# Altium schematic sheet cat-badge.SchDoc(cat-badge)
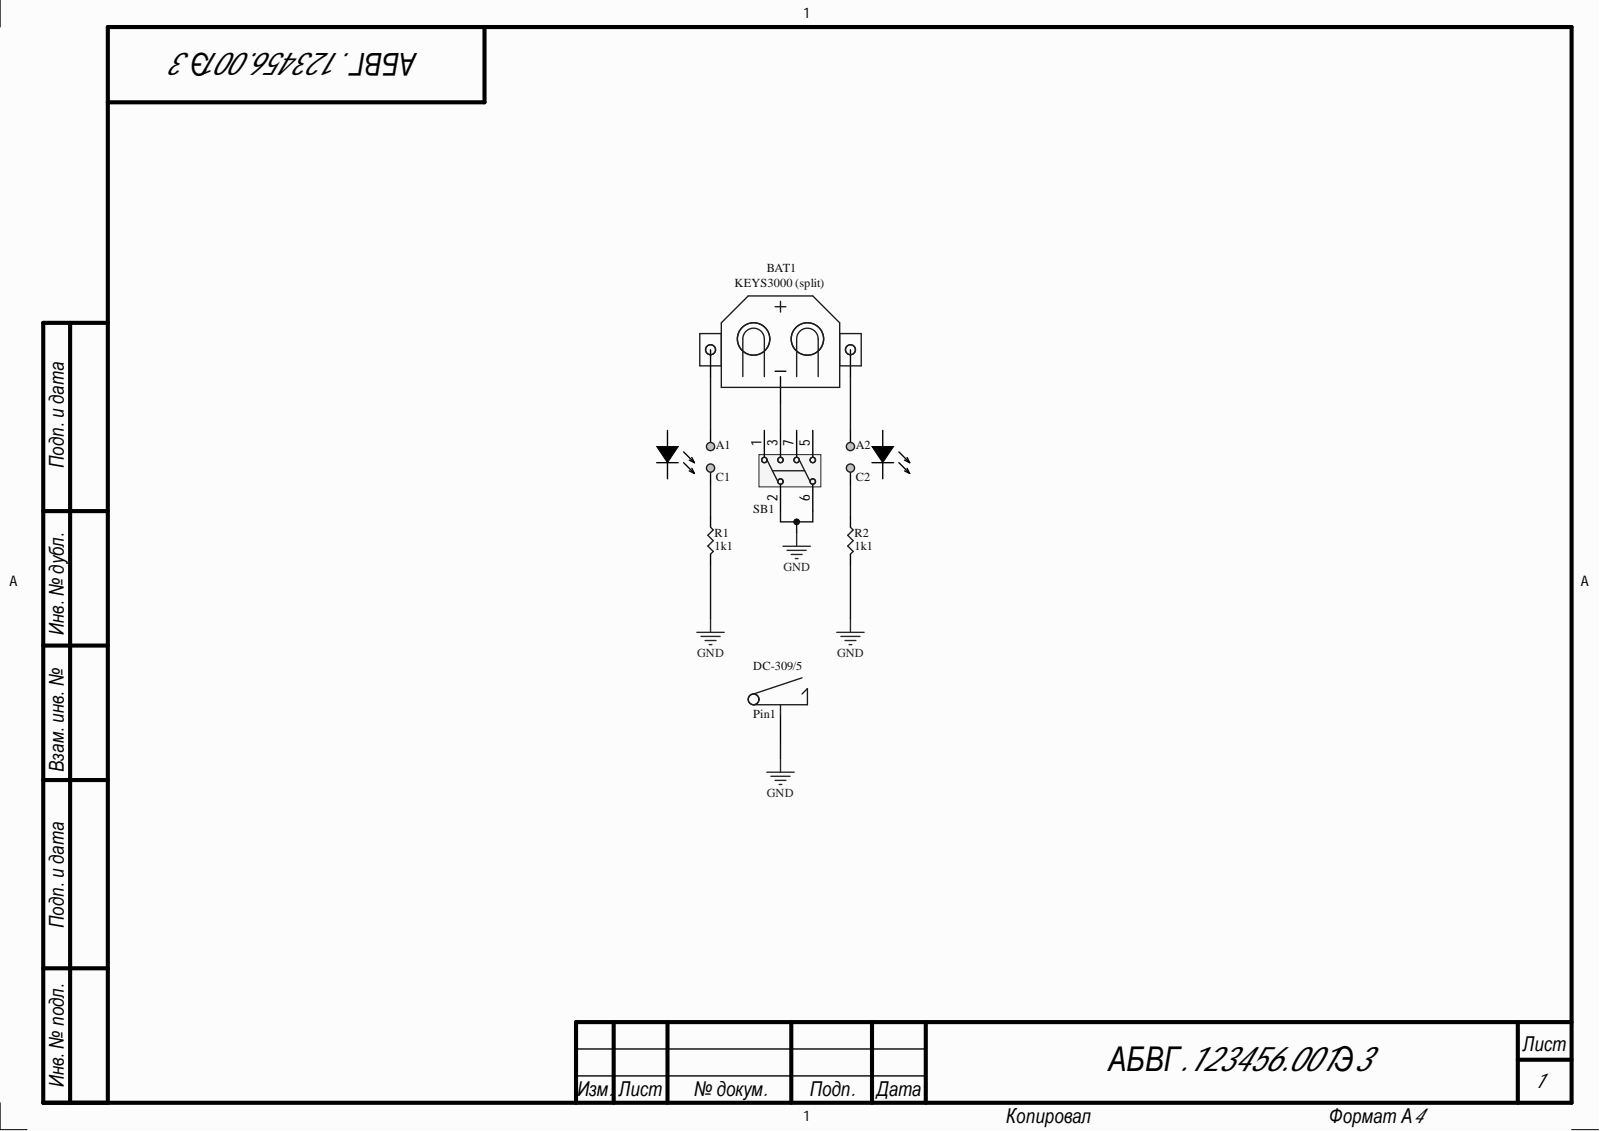
[diagram p0: cat-badge.SchDoc]

## Parts
A1  Test Point  [TP_1.2MM]  (value not drawn)  (no BOM)
A2  Test Point  [TP_1.2MM]  (value not drawn)  (no BOM)
BAT1  KEYS3000 (split)  [KEYS3000_split]
C1  Test Point  [TP_1.2MM]  (value not drawn)  (no BOM)
C2  Test Point  [TP_1.2MM]  (value not drawn)  (no BOM)
Pin1  DC-309/5  [DC-309/5]
R1  1k1  [RES0603]
R2  1k1  [RES0603]
SB1  JS202011JCQN  [JS202011JCQN]  (value not drawn)

## Nets
GND: Pin1.1 R1.1 R2.1 SB1.2 SB1.6
NetA1_1: A1.1 BAT1.3
NetA2_1: A2.1 BAT1.1
NetBAT1_2: BAT1.2 SB1.3
NetC1_1: C1.1 R1.2
NetC2_1: C2.1 R2.2

Not connected: SB1 1 5 7

## Library data of the parts
A1 A2 C1 C2 = TP/R1.2: Database Table Name: Test Point$; Item: TP1.2; Category: Test Point; Part Number: TP/R1.2; Library Name: Lib_Kam.DbLib
BAT1 = KEYS3000 (split): Coin Cell Battery Holders SMT HOLDER FOR CR1220; Manufacturer: KEYSTONE; Database Table Name: Other$; Item: 1220 battery holder split shield; Case: BH611220-P; Category: Coin Cell Battery Holders; Component Kind: Standard; HelpURL: https://static.chipdip.ru/lib/181/DOC014181351.pdf; Part Number: KEYS3000 (split); Supplier 1: BelChip; Supplier Part Number 1: 48852; Library Name: Lib_Kam.DbLib
Pin1 = DC-309/5: Bar pin 20mm; Manufacturer: none; Database Table Name: Other$; Item: Bar-pin 20mm; Case: none; Category: Mechanical; Component Kind: Standard; HelpURL: none; Part Number: DC-309/5; Supplier 1: none; Supplier Part Number 1: none; Library Name: Lib_Kam.DbLib
R1 R2 = ERJ-3EKF1101V: Tolerance ±1% Power (Watts) 0.1W, 1/10W Temperature Coefficient ±100ppm/°C; Manufacturer: Panasonic; Database Table Name: Resistors$; Item: 1k1  ±1% 0.1W; Case: 0603; Category: Thin Film Resistors - SMD; Component Kind: Standard; HelpURL: https://eu.mouser.com/datasheet/2/315/AOA0000C304-1149620.pdf; Part Number: ERJ-3EKF1101V; Supplier 1: Mouser; Supplier Part Number 1: 667-ERJ-3EKF1101V; Tolerance: 1%; Value: 1k1; Library Name: Lib_Kam.DbLib
SB1 = JS202011JCQN: Slide Switches DPDT .3A; Manufacturer: C&K Switches; Database Table Name: Switch$; Switch Function: ON  - ON; Vdss: 6V; Case: SMD/SMT; Category: Slide Switches; Component Kind: Standard; HelpURL: https://www.ckswitches.com/media/1422/js.pdf; Item: JS202011JCQN; Mechanical Life: N/A; Part Number: JS202011JCQN; Supplier: Chip-Dip; Library Name: Lib_Kam.DbLib
